# Altium schematic sheet sheet01.SchDoc
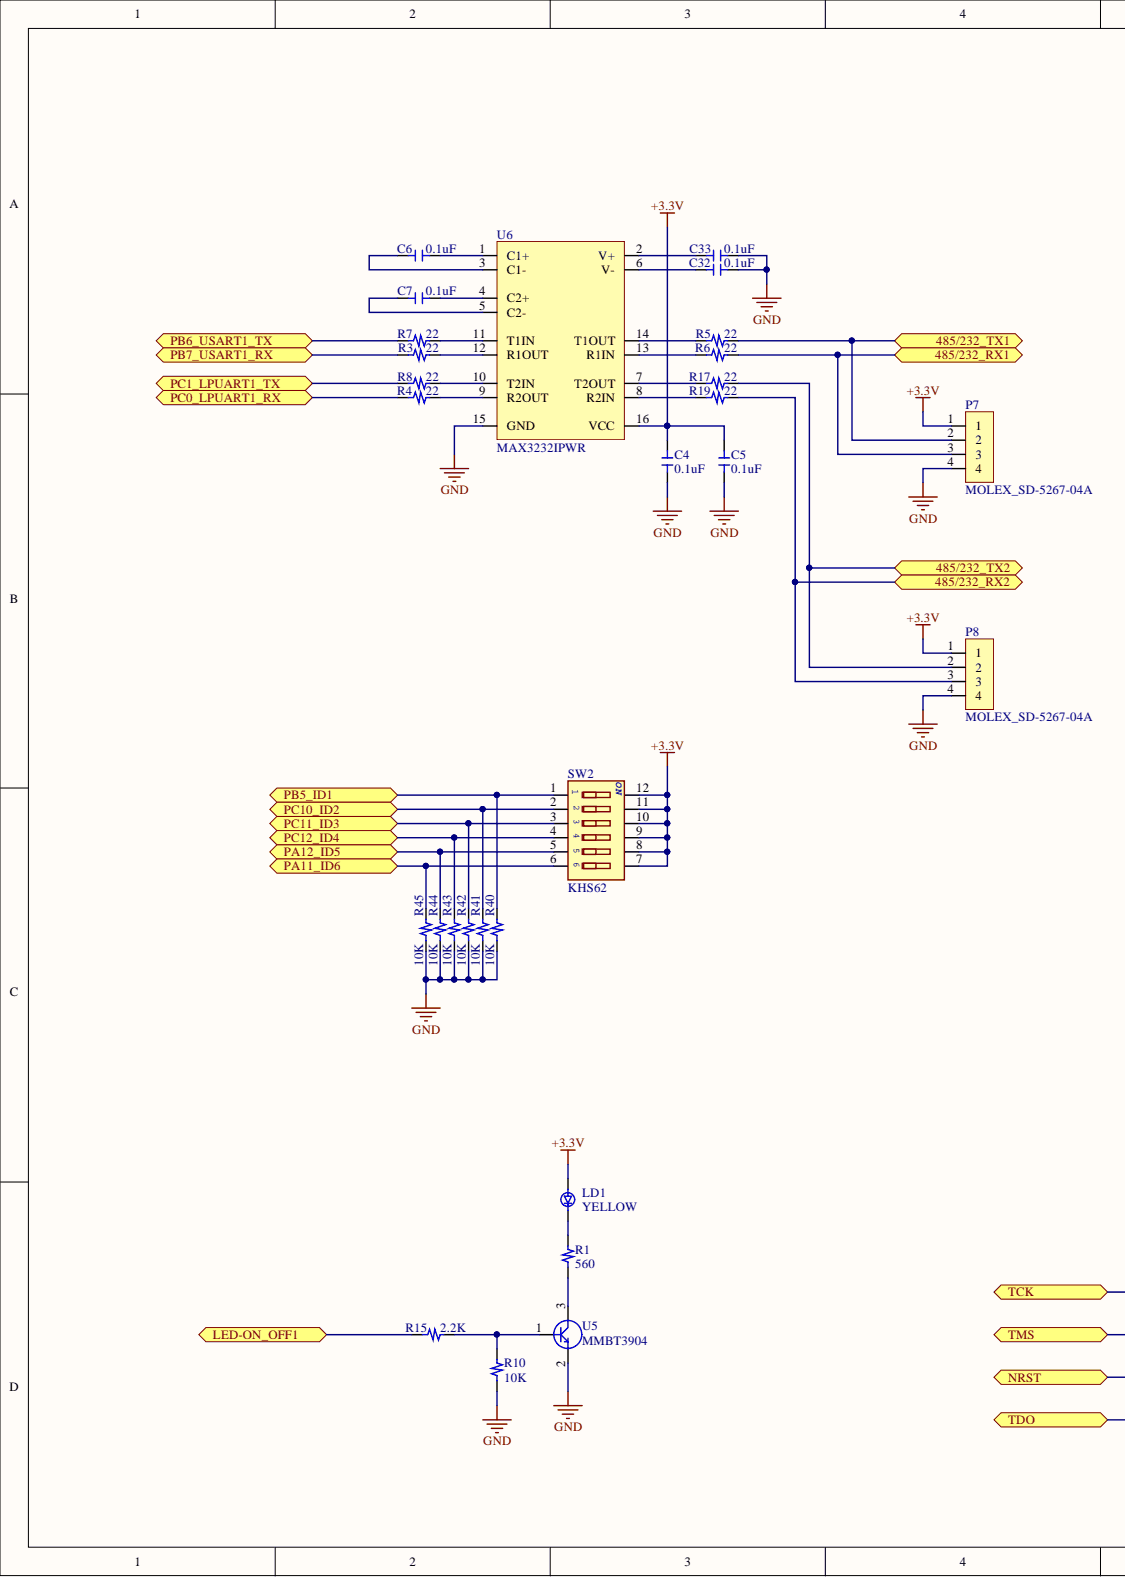
[diagram p0: sheet01.SchDoc, left]
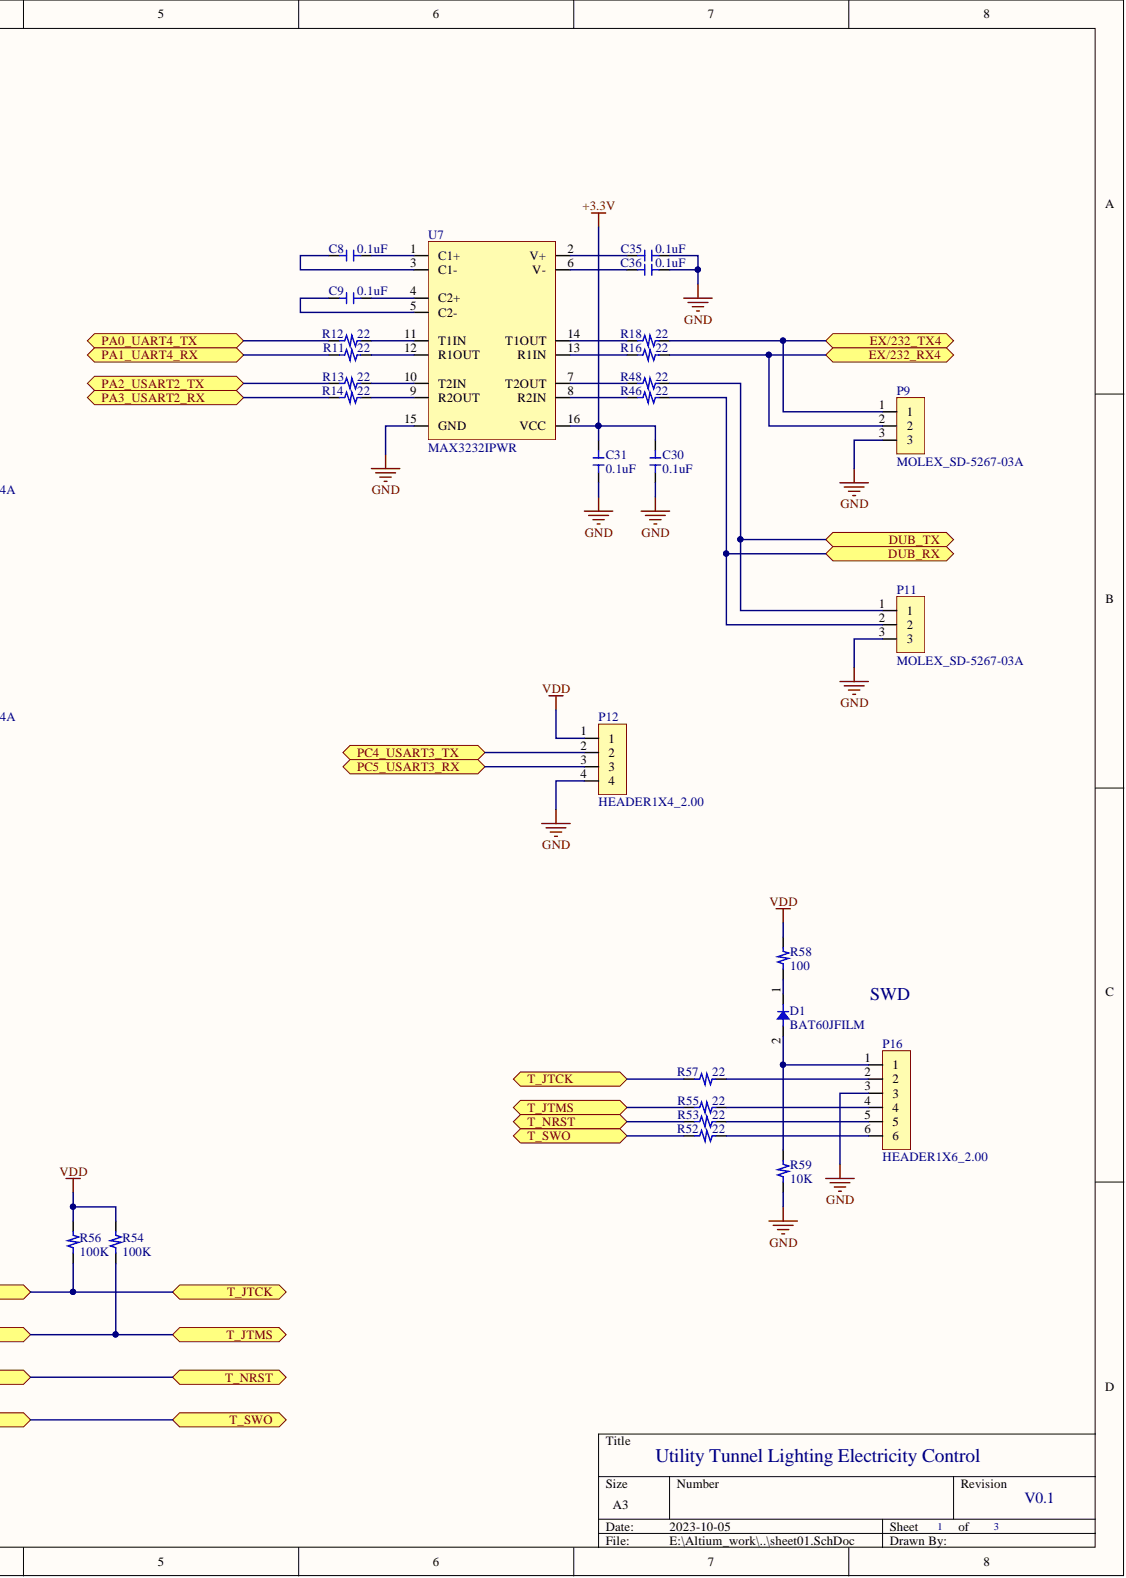
[diagram p1: sheet01.SchDoc, right]

## Parts
C4  0.1uF  [CC1608]
C5  0.1uF  [CC1608]
C6  0.1uF  [CC1608]
C7  0.1uF  [CC1608]
C8  0.1uF  [CC1608]
C9  0.1uF  [CC1608]
C30  0.1uF  [CC1608]
C31  0.1uF  [CC1608]
C32  0.1uF  [CC1608]
C33  0.1uF  [CC1608]
C35  0.1uF  [CC1608]
C36  0.1uF  [CC1608]
D1  BAT60JFILM  [SOD-323_1.7X1.3_H1.1]
LD1  YELLOW  [LED2012]
P7  MOLEX_SD-5267-04A  [MOLEX_SD-5267-04A]
P8  MOLEX_SD-5267-04A  [MOLEX_SD-5267-04A]
P9  MOLEX_SD-5267-03A  [MOLEX_SD-5267-03A]
P11  MOLEX_SD-5267-03A  [MOLEX_SD-5267-03A]
P12  HEADER1X4_2.00  [HEADER1X4_2.00]
P16  HEADER1X6_2.00  [HEADER1X6_2.00]
R1  560  [RC1608]
R3  22  [RC1608]
R4  22  [RC1608]
R5  22  [RC1608]
R6  22  [RC1608]
R7  22  [RC1608]
R8  22  [RC1608]
R10  10K  [RC1608]
R11  22  [RC1608]
R12  22  [RC1608]
R13  22  [RC1608]
R14  22  [RC1608]
R15  2.2K  [RC1608]
R16  22  [RC1608]
R17  22  [RC1608]
R18  22  [RC1608]
R19  22  [RC1608]
R40  10K  [RC1608]
R41  10K  [RC1608]
R42  10K  [RC1608]
R43  10K  [RC1608]
R44  10K  [RC1608]
R45  10K  [RC1608]
R46  22  [RC1608]
R48  22  [RC1608]
R52  22  [RC1608]
R53  22  [RC1608]
R54  100K  [RC1608]
R55  22  [RC1608]
R56  100K  [RC1608]
R57  22  [RC1608]
R58  100  [RC1608]
R59  10K  [RC1608]
SW2  KHS62  [DIPSW-KHS62]
U5  MMBT3904  [SOT23-3_2.9X1.3_0.95_H1.22]
U6  MAX3232IPWR  [TSSOP16_5.0X4.4_0.65_H1.2]
U7  MAX3232IPWR  [TSSOP16_5.0X4.4_0.65_H1.2]

## Ports
485/232_RX1, 485/232_RX2, 485/232_TX1, 485/232_TX2, DUB_RX, DUB_TX, EX/232_RX4, EX/232_TX4, LED-ON_OFF1, NRST, PA0_UART4_TX, PA1_UART4_RX, PA2_USART2_TX, PA3_USART2_RX, PA11_ID6, PA12_ID5, PB5_ID1, PB6_USART1_TX, PB7_USART1_RX, PC0_LPUART1_RX, PC1_LPUART1_TX, PC4_USART3_TX, PC5_USART3_RX, PC10_ID2, PC11_ID3, PC12_ID4, T_JTCK, T_JTMS, T_NRST, T_SWO, TCK, TDO, TMS

## Nets
+3.3V: C4.2 C5.2 C30.2 C31.2 LD1.2 P7.1 P8.1 SW2.7 SW2.8 SW2.9 SW2.10 SW2.11 SW2.12 U6.16(VCC) U7.16(VCC)
GND: C4.1 C5.1 C30.1 C31.1 C32.2 C33.2 C35.2 C36.2 P7.4 P8.4 P9.3 P11.3 P12.4 P16.3 R10.1 R40.1 R41.1 R42.1 R43.1 R44.1 R45.1 R59.1 U5.2 U6.15(GND) U7.15(GND)
VDD: P12.1 R54.2 R56.2 R58.2
485/232_RX1: P7.3 R6.2 ; port 485/232_RX1
485/232_RX2: P8.3 R19.2 ; port 485/232_RX2
485/232_TX1: P7.2 R5.2 ; port 485/232_TX1
485/232_TX2: P8.2 R17.2 ; port 485/232_TX2
DUB_RX: P11.2 R46.2 ; port DUB_RX
DUB_TX: P11.1 R48.2 ; port DUB_TX
EX/232_RX4: P9.2 R16.2 ; port EX/232_RX4
EX/232_TX4: P9.1 R18.2 ; port EX/232_TX4
LED-ON_OFF1: R15.1 ; port LED-ON_OFF1
NRST: R53.1 ; port NRST, port T_NRST
PA0_UART4_TX: R12.1 ; port PA0_UART4_TX
PA1_UART4_RX: R11.1 ; port PA1_UART4_RX
PA2_USART2_TX: R13.1 ; port PA2_USART2_TX
PA3_USART2_RX: R14.1 ; port PA3_USART2_RX
PA11_ID6: R45.2 SW2.6 ; port PA11_ID6
PA12_ID5: R44.2 SW2.5 ; port PA12_ID5
PB5_ID1: R40.2 SW2.1 ; port PB5_ID1
PB6_USART1_TX: R7.1 ; port PB6_USART1_TX
PB7_USART1_RX: R3.1 ; port PB7_USART1_RX
PC0_LPUART1_RX: R4.1 ; port PC0_LPUART1_RX
PC1_LPUART1_TX: R8.1 ; port PC1_LPUART1_TX
PC4_USART3_TX: P12.2 ; port PC4_USART3_TX
PC5_USART3_RX: P12.3 ; port PC5_USART3_RX
PC10_ID2: R41.2 SW2.2 ; port PC10_ID2
PC11_ID3: R42.2 SW2.3 ; port PC11_ID3
PC12_ID4: R43.2 SW2.4 ; port PC12_ID4
T_JTCK: R56.1 R57.1 ; port TCK, port T_JTCK
T_JTMS: R54.1 R55.1 ; port TMS, port T_JTMS
T_SWO: R52.1 ; port TDO, port T_SWO
NetC6_1: C6.1 U6.3(C1-)
NetC6_2: C6.2 U6.1(C1+)
NetC7_1: C7.1 U6.5(C2-)
NetC7_2: C7.2 U6.4(C2+)
NetC8_1: C8.1 U7.3(C1-)
NetC8_2: C8.2 U7.1(C1+)
NetC9_1: C9.1 U7.5(C2-)
NetC9_2: C9.2 U7.4(C2+)
NetC32_1: C32.1 U6.6(V-)
NetC33_1: C33.1 U6.2(V+)
NetC35_1: C35.1 U7.2(V+)
NetC36_1: C36.1 U7.6(V-)
NetD1_1: D1.1 R58.1
NetD1_2: D1.2 P16.1 R59.2
NetLD1_1: LD1.1 R1.2
NetP16_2: P16.2 R57.2
NetP16_4: P16.4 R55.2
NetP16_5: P16.5 R53.2
NetP16_6: P16.6 R52.2
NetR1_1: R1.1 U5.3
NetR3_2: R3.2 U6.12(R1OUT)
NetR4_2: R4.2 U6.9(R2OUT)
NetR5_1: R5.1 U6.14(T1OUT)
NetR6_1: R6.1 U6.13(R1IN)
NetR7_2: R7.2 U6.11(T1IN)
NetR8_2: R8.2 U6.10(T2IN)
NetR10_2: R10.2 R15.2 U5.1
NetR11_2: R11.2 U7.12(R1OUT)
NetR12_2: R12.2 U7.11(T1IN)
NetR13_2: R13.2 U7.10(T2IN)
NetR14_2: R14.2 U7.9(R2OUT)
NetR16_1: R16.1 U7.13(R1IN)
NetR17_1: R17.1 U6.7(T2OUT)
NetR18_1: R18.1 U7.14(T1OUT)
NetR19_1: R19.1 U6.8(R2IN)
NetR46_1: R46.1 U7.8(R2IN)
NetR48_1: R48.1 U7.7(T2OUT)

## Library data of the parts
C4-C9 C30-C33 C35 C36 = CAP: Ceramic CAP; Part: Passive; Component Kind: Standard; Contact Point: Contact Point; Library Name: knb_lib.IntLib
LD1 = LED: Component Kind: Standard; Library Name: knb_lib.IntLib
R1 R3-R8 R10-R19 R40-R46 R48 R52-R59 = RES: Part: Passive; Component Kind: Standard; Description: RES; Library Name: knb_lib.IntLib
SW2 = DIPSW_KHS62: Component Kind: Standard; Library Name: SW_XTAL_PWR_ETC.SchLib
D1 = BAT60JFILM: Component Kind: Standard; Library Name: RLC.SchLib
P7 P8 = MOLEX_SD-5267-04A: Component Kind: Standard; Library Name: CONNECTOR.SchLib
P9 P11 = MOLEX_SD-5267-03A: Component Kind: Standard; Library Name: CONNECTOR.SchLib
P12 = HEADER1X4_2.00: Component Kind: Standard; Library Name: CONNECTOR.SchLib
P16 = HEADER1X6_2.00: Component Kind: Standard; Library Name: CONNECTOR.SchLib
U5 = MMBT3904: Component Kind: Standard; Library Name: knb_lib.IntLib
U6 U7 = MAX3232IPWR: Component Kind: Standard; Library Name: IC.SchLib
